# Altium schematic sheet Sensors-1.SchDoc(Sensors-1)
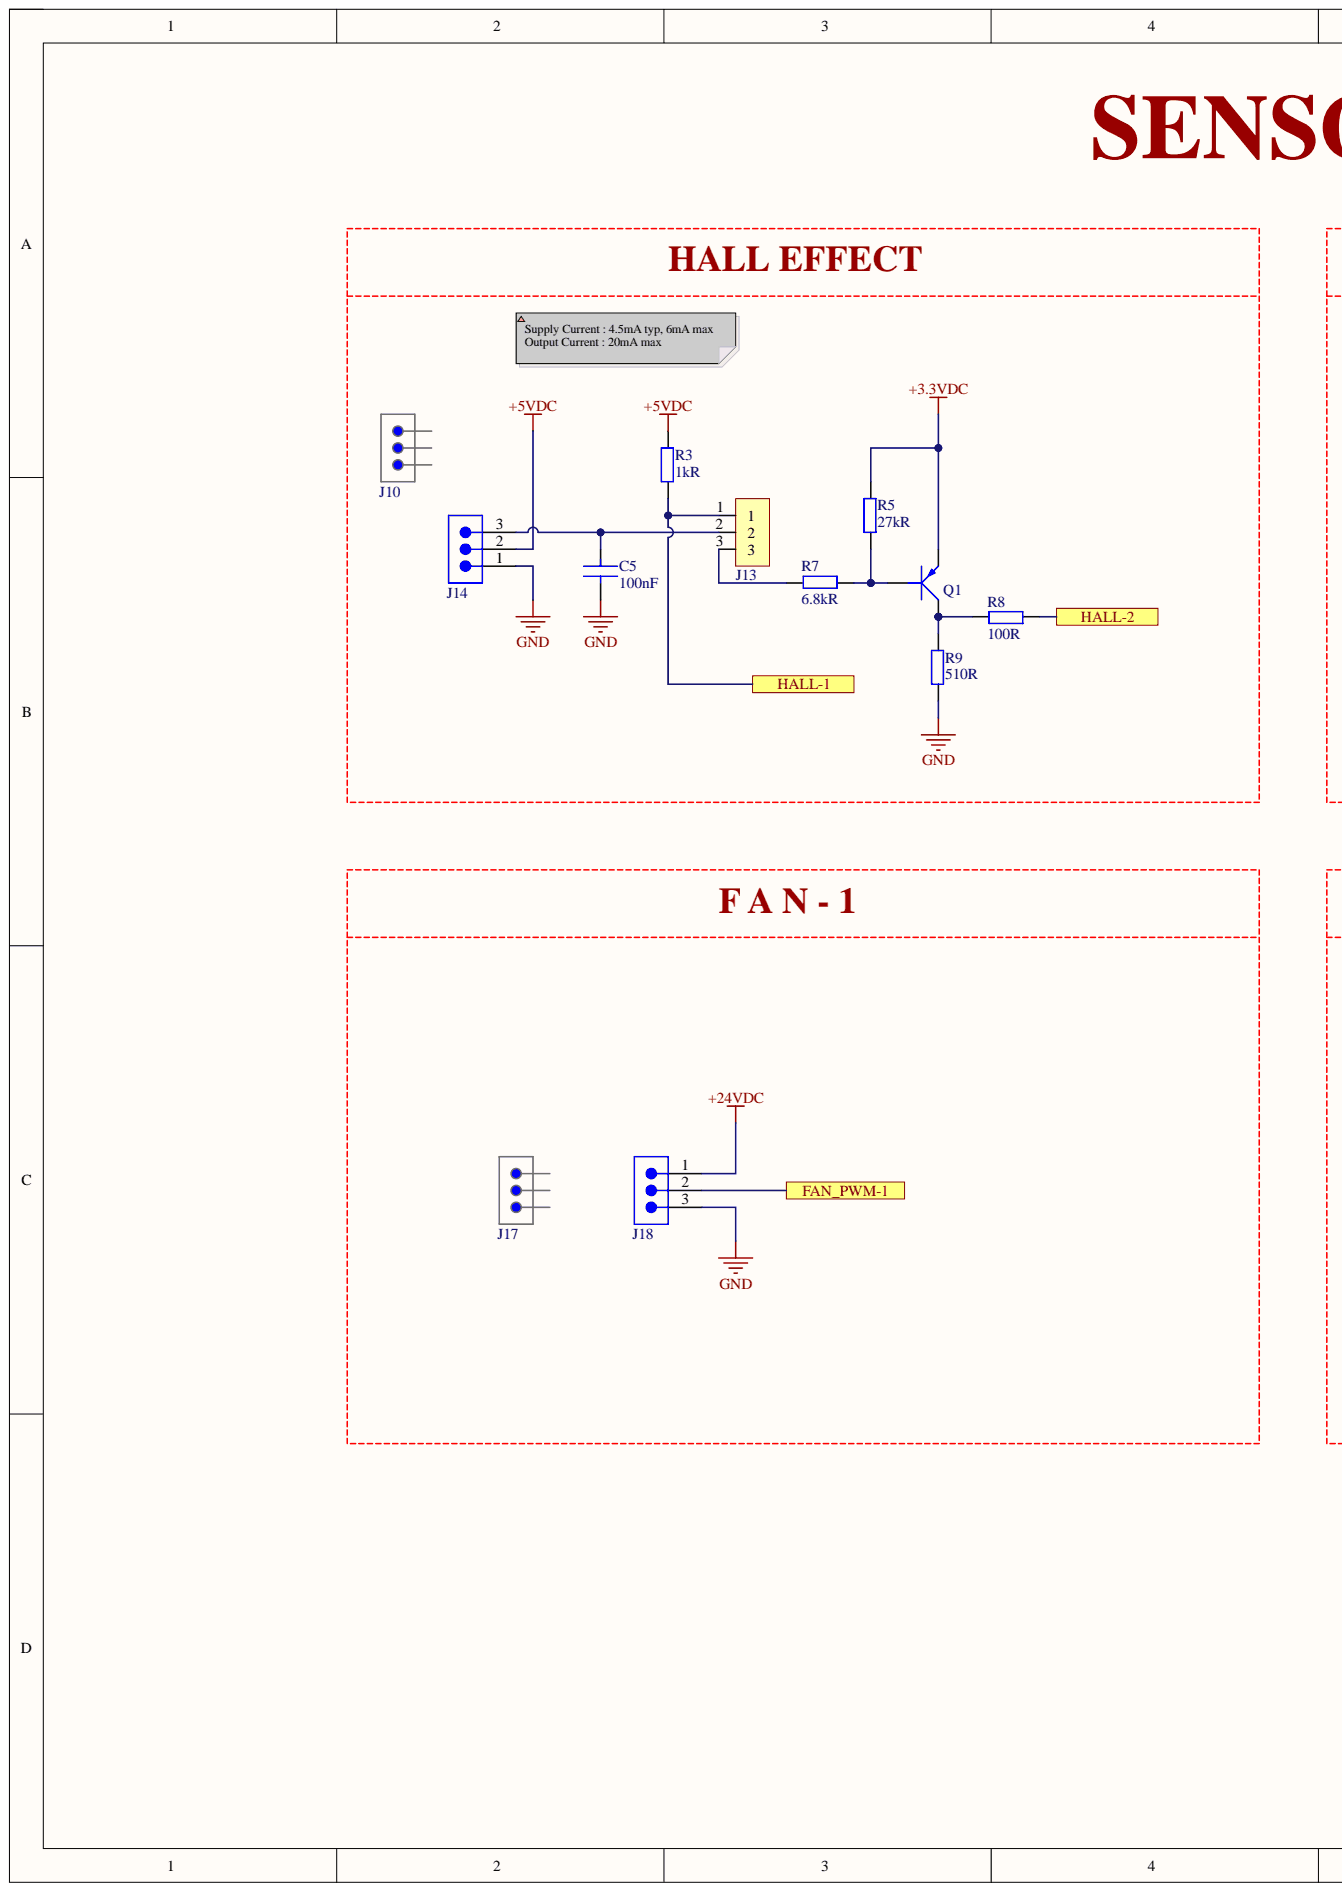
[diagram p0: Sensors-1.SchDoc, left]
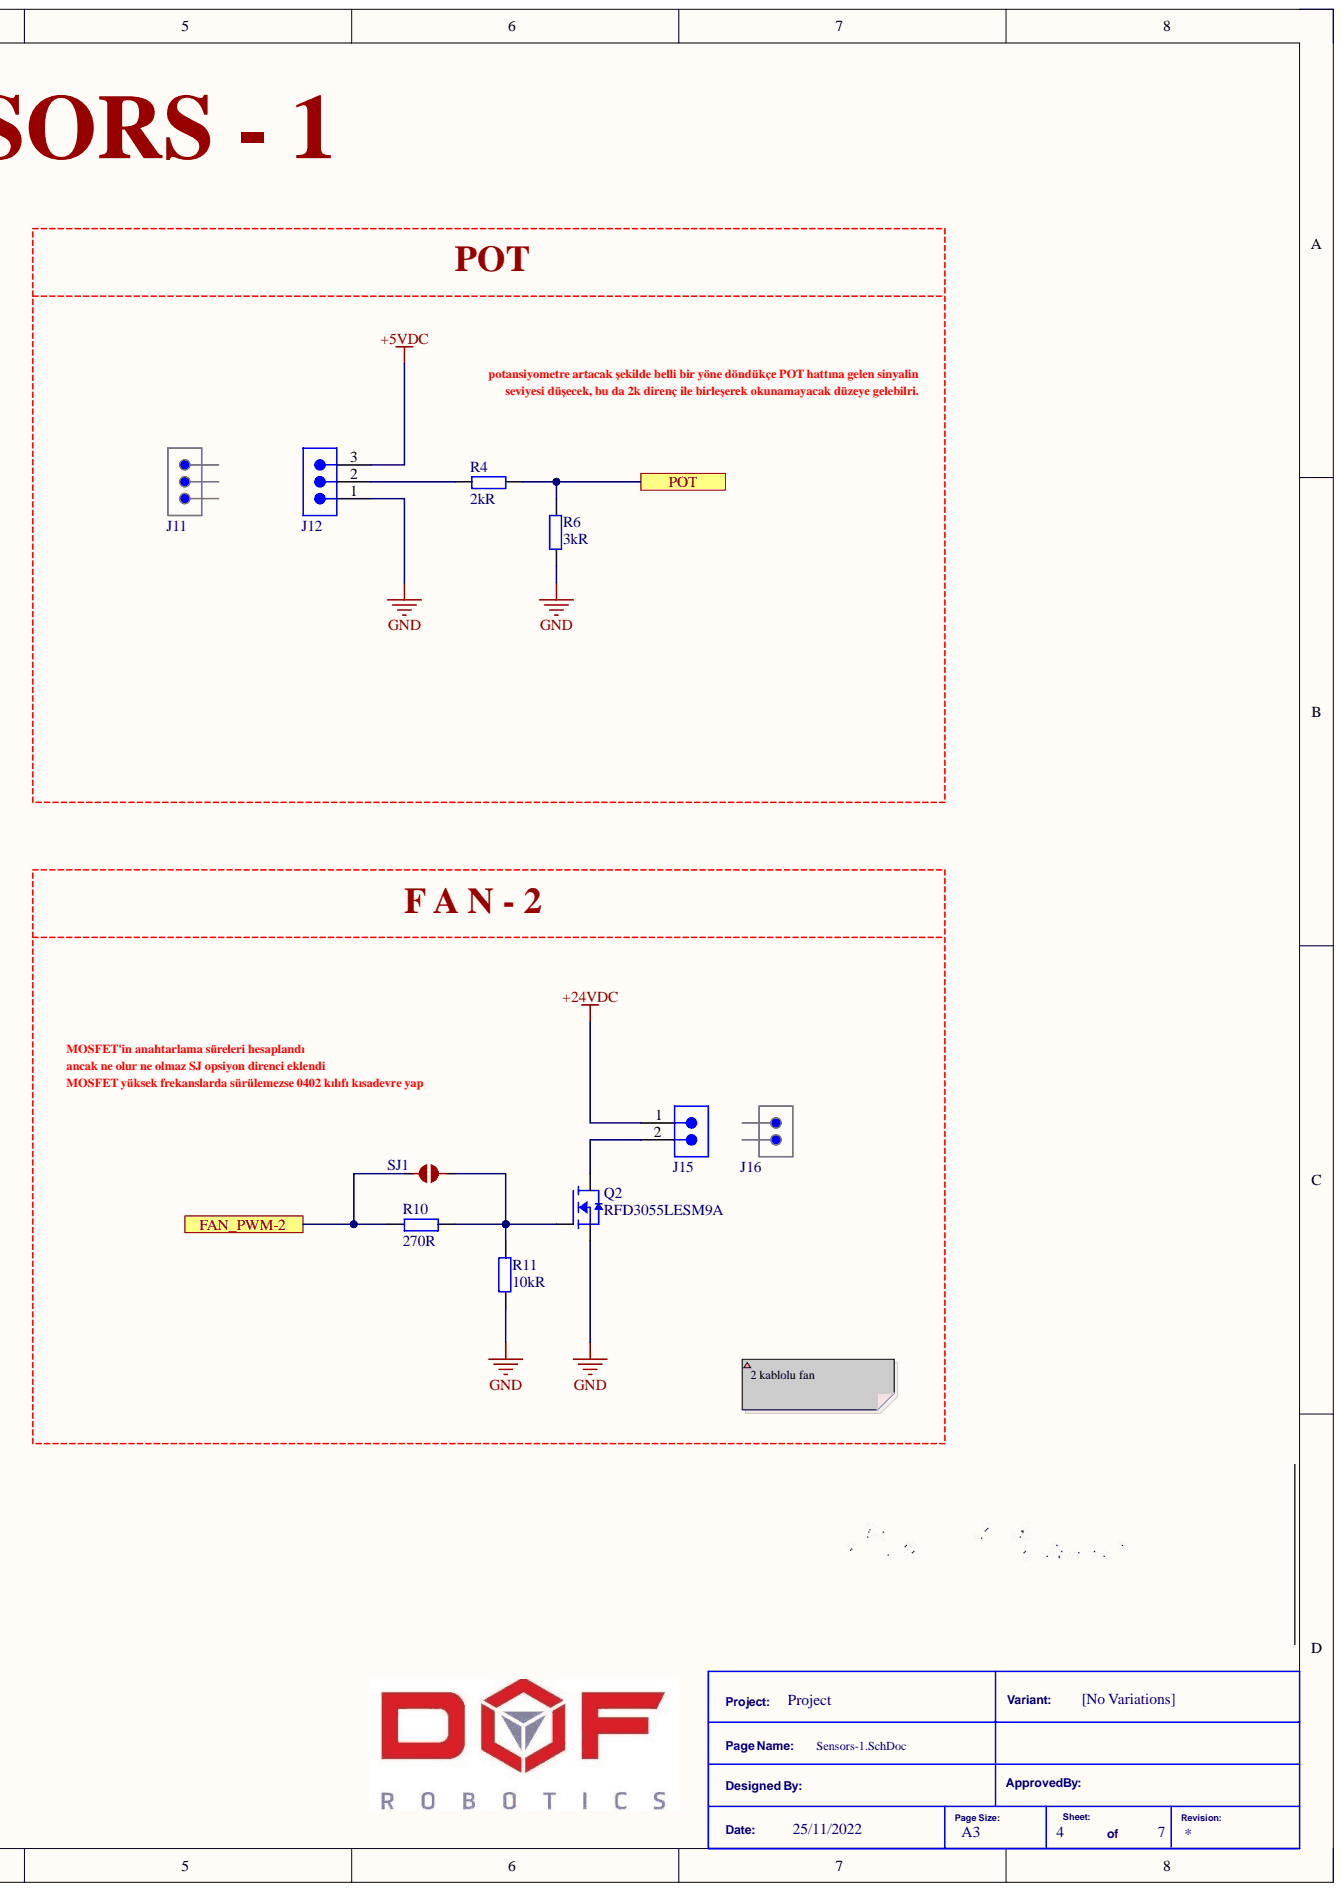
[diagram p1: Sensors-1.SchDoc, right]

## Parts
C5  Capacitance: 100nF  [CAP CER 0805]  (hidden comment: CL21B104KACNNNC)
J10  691352710003  [CONN FML 1X3 WURTH 691352710003]  (value not drawn)
J11  691352710003  [CONN FML 1X3 WURTH 691352710003]  (value not drawn)
J12  691313710003  [CONN ML 1X3 WURTH 691313710003]  (value not drawn)
J13  61300311121  [HDR_ML_1X3]  (value not drawn)
J14  691313710003  [CONN ML 1X3 WURTH 691313710003]  (value not drawn)
J15  691313710002  [CONN ML 1X2 WURTH 691313710002]  (value not drawn)
J16  691352710002  [CONN FML 1X2 WURTH 691352710002]  (value not drawn)
J17  691352710003  [CONN FML 1X3 WURTH 691352710003]  (value not drawn)
J18  691313710003  [CONN ML 1X3 WURTH 691313710003]  (value not drawn)
Q1  MMSS8550-H-TP  [SOT23-3]  (value not drawn)
Q2  RFD3055LESM9A  [TO-252AA]
R3  Resistance: 1kR  [RES 0603]  (hidden comment: ERJ-PA3J102V)
R4  Resistance: 2kR  [RES 0603]  (hidden comment: ERJ-3EKF2001V)
R5  Resistance: 27kR  [RES 0805]  (hidden comment: ERJ-6ENF2702V)
R6  Resistance: 3kR  [RES 0603]  (hidden comment: AC0603FR-073KL)
R7  Resistance: 6.8kR  [RES 0603]  (hidden comment: ERJ-PA3J682V)
R8  Resistance: 100R  [RES 0805]  (hidden comment: ERJ-P06J101V)
R9  Resistance: 510R  [RES 0603]  (hidden comment: ESR03EZPJ511)
R10  Resistance: 270R  [RES 0805]  (hidden comment: CRGCQ0805F270R)
R11  Resistance: 10kR  [RES 0805]  (hidden comment: RNCP0805FTD10K0)
SJ1  0402 jumper  [Res 0402]  (value not drawn)  (no BOM)

## Ports
FAN_PWM-1, FAN_PWM-2, HALL-1, HALL-2, POT

## Nets
+3.3VDC: Q1.E R5.2
+5VDC: J12.3 J14.2 R3.2
+24VDC: J15.1 J18.1
GND: C5.1 J12.1 J14.1 J18.3 Q2.2 R6.1 R9.1 R11.1
FAN_PWM-1: J18.2 ; port FAN_PWM-1
FAN_PWM-2: R10.2 SJ1.1 ; port FAN_PWM-2
HALL-1: J13.1 R3.1 ; port HALL-1
HALL-2: R8.1 ; port HALL-2
POT: R4.1 R6.2 ; port POT
NetC5_2: C5.2 J13.2 J14.3
NetJ12_2: J12.2 R4.2
NetJ13_3: J13.3 R7.1
NetJ15_2: J15.2 Q2.3
NetQ1_B: Q1.B R5.1 R7.2
NetQ1_C: Q1.C R8.2 R9.2
NetQ2_1: Q2.1 R10.1 R11.2 SJ1.2

## Library data of the parts
C5 = CAP CER 0.1UF 25V X7R 0805: Manufacturer: Samsung; Tolerance: 10%; Case Code (Imperial): 0805; Case Code (Metric): 2012; Case/Package: 0805; Category: Ceramic Capacitors; Component Kind: Standard; ComponentLink1Description: https://datasheet.ciiva.com/25801/cl21b104kacnnnc-25801824.pdf?src-supplier=Digi-Key; ComponentLink1URL: https://datasheet.ciiva.com/25801/cl21b104kacnnnc-25801824.pdf?src-supplier=Digi-Key; ComponentLink2Description: https://datasheet.ciiva.com/15216/getdatasheetpartid-355204-15216236.pdf?src-supplier=Verical; ComponentLink2URL: https://datasheet.ciiva.com/15216/getdatasheetpartid-355204-15216236.pdf?src-supplier=Verical; Construction: Flat; Contact Plating: Tin; Depth: 1.25mm; Description: CAP CER 0.1UF 25V X7R 0805; Dielectric: X7R; Height: 0.85mm; Length: 2mm; Manufacturer Part Number: CL21B104KACNNNC; Max Operating Temperature: 125°C; Min Operating Temperature: -55°C; Mount: Surface Mount; Package Quantity: 1; Packaging: Tape and Reel; Pricing 1: 1=0.10000, 10=0.04000, 50=0.02200, 100=0.01790, 500=0.01282, 1000=0.01007 (USD); Radiation Hardening: No; RoHS: Yes; RoHS Compliant: Yes; Series: CL; Stock 1: 2617; Supplier 1: Digi-Key; Supplier Part Number 1: 1276-1099-1-ND; Thickness: 0.037inch; Voltage: 25V; Voltage Rating: 25V; Width: 0.049inch; Library Name: LibEnes.SchLib
J10 J11 J17 = CONN FML 1X3 WURTH 691352710003: Pluggable Terminal Block, 3, 300 V, 12 A, 5 Mm, 24 Awg, 12 Awg Rohs Compliant: Yes  (Mate to 691313710003); Manufacturer: Wurth Electronics; Current: 20A; Current Rating: 12A; Number of Contacts: 3; Number of Levels: 1; Pitch: 0.197inch; Stripping Length: -7mm; Voltage: 300V; Wire Gauge (Max): 24AWG; Wire Gauge (Min): 12AWG; Category: Terminal Blocks; Color: Green; Component Kind: Standard; ComponentLink1Description: https://datasheet.ciiva.com/7992/1442233-7992380.pdf?src-supplier=Element14; ComponentLink1URL: https://datasheet.ciiva.com/7992/1442233-7992380.pdf?src-supplier=Element14; ComponentLink2Description: https://datasheet.ciiva.com/796/6913527100xx-796216.pdf?src-supplier=Digi-Key; ComponentLink2URL: https://datasheet.ciiva.com/796/6913527100xx-796216.pdf?src-supplier=Digi-Key; Contact Material: Brass; Length: 18.2mm; Manufacturer Part Number: 691352710003; Mount: Free Hanging; Number of Positions Per Level: 3; Package Quantity: 250; Packaging: Bulk; Pricing 1: 1=2.05000, 10=1.83900, 50=1.58800, 100=1.42100, 250=1.33700, 500=1.25400 (USD); REACH SVHC: Unknown; RoHS: Yes; RoHS Compliant: Yes; Series: WR-TBL Series 3527; Stock 1: 2478; Supplier 1: Digi-Key; Supplier Part Number 1: 732-2776-ND; Termination: Screw; Voltage Rating: 300V; Width: 15mm; Wire/Cable Gauge: 24AWG; Library Name: LibEnes.SchLib
J12 J14 J18 = CONN ML 1X3 WURTH 691313710003: 5mm Pitch Right Angle Pluggable Term Block; PCB Header; Through Hole; 3 Way  (Mate to 691352710003); Manufacturer: Wurth Electronics; Connector Type: PCB,Terminal Block; Current: 20A; Number of Contacts: 3; Number of Levels: 1; Pitch: 0.197inch; Voltage: 300V; Category: Terminal Blocks; Color: Green; Component Kind: Standard; ComponentLink1Description: https://datasheet.ciiva.com/8043/1442229-8043534.pdf?src-supplier=Element14; ComponentLink1URL: https://datasheet.ciiva.com/8043/1442229-8043534.pdf?src-supplier=Element14; ComponentLink2Description: https://datasheet.ciiva.com/795/6913137100xx-795838.pdf?src-supplier=Digi-Key; ComponentLink2URL: https://datasheet.ciiva.com/795/6913137100xx-795838.pdf?src-supplier=Digi-Key; ComponentLink3Description: https://datasheet.ciiva.com/48692/001088692-da-01-en-wr-tbl-terminal-block3137-691313710003-48692901.pdf?src-supplier=Conrad; ComponentLink3URL: https://datasheet.ciiva.com/48692/001088692-da-01-en-wr-tbl-terminal-block3137-691313710003-48692901.pdf?src-supplier=Conrad; Contact Material: Brass; Height: 12mm; Length: 12.5mm; Manufacturer Part Number: 691313710003; Mount: Through Hole; Number of Positions Per Level: 3; Number of Rows: 1; Package Quantity: 450; Packaging: Bulk; Pricing 1: 1=0.74000, 10=0.65700, 50=0.56800, 100=0.50800, 200=0.47800, 400=0.44800 (USD); REACH SVHC: Unknown; RoHS: Yes; RoHS Compliant: Yes; Series: WR-TBL Series 3137; Stock 1: 1376; Supplier 1: Digi-Key; Supplier Part Number 1: 732-2800-ND; Termination: Solder; Width: 17mm; Library Name: LibEnes.SchLib
J13 = HDR ML 1X3 WURTH 61300311121: CONN HEADER 3 POS 2.54; Manufacturer: Wurth Electronics; Connector Type: Male Pin; Gender: Male; Number of Contacts: 3; Pitch: 0.1inch; Category: Connectors; Color: Black; Component Kind: Standard; ComponentLink1Description: http://www.farnell.com/cad/1737268.pdf?src-supplier=Element14; ComponentLink1URL: http://www.farnell.com/cad/1737268.pdf?src-supplier=Element14; ComponentLink2Description: https://katalog.we-online.de/em/datasheet/6130xx11121.pdf?src-supplier=Digi-Key; ComponentLink2URL: https://katalog.we-online.de/em/datasheet/6130xx11121.pdf?src-supplier=Digi-Key; Contact Material: Copper; Contact Mating Length: 0.236inch; Contact Plating: Gold; Lead Free: Lead Free; Manufacturer Part Number: 61300311121; Mount: Through Hole; Number of Positions: 3; Number of Rows: 1; Packaging: Bulk; Pricing 1: 1=0.13000, 10=0.11300, 25=0.09400, 50=0.07900, 100=0.07100, 1000=0.06600 (USD); REACH SVHC: Unknown; RoHS: Yes; RoHS Compliant: Yes; Stock 1: 76429; Supplier 1: Digi-Key; Supplier Part Number 1: 732-5316-ND; Termination: Solder; Library Name: LibEnes.SchLib
J15 = CONN ML 1X2 WURTH 691313710002: 5mm Pitch Right Angle Pluggable Term Block; PCB Header; Through Hole; 2 Way  (Mate to 691352710002); Manufacturer: Wurth Electronics; Connector Type: PCB,Terminal Block; Current: 20A; Number of Contacts: 2; Number of Levels: 1; Pitch: 0.197inch; Voltage: 300V; Category: Terminal Blocks; Color: Green; Component Kind: Standard; ComponentLink1Description: https://datasheet.ciiva.com/795/6913137100xx-795778.pdf?src-supplier=Digi-Key; ComponentLink1URL: https://datasheet.ciiva.com/795/6913137100xx-795778.pdf?src-supplier=Digi-Key; ComponentLink2Description: https://datasheet.ciiva.com/8043/1442229-8043531.pdf?src-supplier=Element14; ComponentLink2URL: https://datasheet.ciiva.com/8043/1442229-8043531.pdf?src-supplier=Element14; ComponentLink3Description: https://datasheet.ciiva.com/48692/001088691-da-01-en-wr-tbl-terminal-block3137-691313710002-48692902.pdf?src-supplier=Conrad; ComponentLink3URL: https://datasheet.ciiva.com/48692/001088691-da-01-en-wr-tbl-terminal-block3137-691313710002-48692902.pdf?src-supplier=Conrad; Contact Material: Brass; Height: 12mm; Length: 12.5mm; Manufacturer Part Number: 691313710002; Mount: Through Hole; Number of Positions Per Level: 2; Number of Rows: 1; Package Quantity: 600; Packaging: Bulk; Pricing 1: 1=0.44000, 10=0.39400, 50=0.34000, 100=0.30500, 250=0.28600, 600=0.26900 (USD); REACH SVHC: Unknown; RoHS: Yes; RoHS Compliant: Yes; Series: WR-TBL Series 3137; Stock 1: 2898; Supplier 1: Digi-Key; Supplier Part Number 1: 732-2799-ND; Termination: Solder; Width: 12mm; Library Name: LibEnes.SchLib
J16 = CONN FML 1X2 WURTH 691352710002: Conn Terminal Block F 2 POS 5mm Screw RA Cable Mount 20A Box    (Mate to 691313710002); Manufacturer: Wurth Electronics; Current Rating: 12A; Number of Contacts: 2; Number of Levels: 1; Pitch: 0.197inch; Stripping Length: -7mm; Voltage: 300V; Wire Gauge (Max): 24AWG; Wire Gauge (Min): 12AWG; Category: Terminal Blocks; Color: Green; Component Kind: Standard; ComponentLink1Description: https://datasheet.ciiva.com/7992/1442233-7992379.pdf?src-supplier=Element14; ComponentLink1URL: https://datasheet.ciiva.com/7992/1442233-7992379.pdf?src-supplier=Element14; ComponentLink2Description: https://datasheet.ciiva.com/796/6913527100xx-796063.pdf?src-supplier=Digi-Key; ComponentLink2URL: https://datasheet.ciiva.com/796/6913527100xx-796063.pdf?src-supplier=Digi-Key; Contact Material: Brass; Current: 20A; Lead Free: Lead Free; Length: 18.2mm; Manufacturer Part Number: 691352710002; Mount: Free Hanging; Number of Positions Per Level: 2; Package Quantity: 350; Packaging: Bulk; REACH SVHC: Unknown; RoHS: Yes; RoHS Compliant: Yes; Series: WR-TBL Series 3527; Stock 1: 2782; Supplier 1: Digi-Key; Supplier Part Number 1: 732-2775-ND; Supplier Unit Price 1: 1=1.32000, 10=1.18200, 50=1.02200, 100=0.91400, 350=0.85900, 700=0.80500 (USD); Termination: Screw; Voltage Rating: 300V; Width: 10mm; Wire/Cable Gauge: 24AWG; Library Name: LibEnes.SchLib
Q1 = BJT PNP MMSS8550-H-TP: PNP Ic=1.5A Vceo=25V hfe=120~350 fT=100MHz; Manufacturer: MCC; Collector Base Voltage (VCBO): 40V; Collector Emitter Breakdown Voltage: 25V; Collector Emitter Saturation Voltage: 500mV; Element Configuration: Single; Emitter Base Voltage (VEBO): 1.6V; Gain Bandwidth Product: 100MHz; hFE Min: 40; Max Collector Current: 1.5A; Max Power Dissipation: 625mW; Case/Package: SOT-23; Category: BJTs; Collector Emitter Voltage (VCEO): 500mV; Collector-emitter Voltage-Max: 500mV; Component Kind: Standard; ComponentLink1Description: https://datasheet.ciiva.com/16285/mmss8550sot-23-12703-16285519.pdf?src-supplier=Mouser; ComponentLink1URL: https://datasheet.ciiva.com/16285/mmss8550sot-23-12703-16285519.pdf?src-supplier=Mouser; ComponentLink2Description: https://datasheet.ciiva.com/17380/mmss8550sot-23-17380226.pdf?src-supplier=Future+Electronics; ComponentLink2URL: https://datasheet.ciiva.com/17380/mmss8550sot-23-17380226.pdf?src-supplier=Future+Electronics; ComponentLink3Description: https://datasheet.ciiva.com/6356/mmss8550sot-23-6356926.pdf?src-supplier=Digi-Key; ComponentLink3URL: https://datasheet.ciiva.com/6356/mmss8550sot-23-6356926.pdf?src-supplier=Digi-Key; ComponentLink4Description: https://datasheet.ciiva.com/6356/mmss8550sot-23-6356927.pdf?src-supplier=Digi-Key; ComponentLink4URL: https://datasheet.ciiva.com/6356/mmss8550sot-23-6356927.pdf?src-supplier=Digi-Key; ComponentLink5Description: https://datasheet.ciiva.com/6356/mmss8550sot-23-6356925.pdf?src-supplier=Digi-Key; ComponentLink5URL: https://datasheet.ciiva.com/6356/mmss8550sot-23-6356925.pdf?src-supplier=Digi-Key; Lead Free: Lead Free; Manufacturer Part Number: MMSS8550-H-TP; Max Breakdown Voltage: 25V; Max Operating Temperature: 150°C; Min Operating Temperature: -55°C; Mount: Surface Mount; Package Quantity: 1; Packaging: Tape and Reel; Polarity: PNP; Pricing 1: 1=0.27000, 10=0.22000, 100=0.11690, 500=0.07692, 1000=0.05230 (USD); RoHS: Yes; RoHS Compliant: Yes; Series: -; Stock 1: 100985; Supplier 1: Digi-Key; Supplier Part Number 1: MMSS8550-H-TPMSCT-ND; Transition Frequency: 100MHz; Library Name: LibEnes.SchLib
Q2 = MOS NPN RFD3055LESM9A: Trans MOSFET N-CH 60V 11A 3-Pin(2+Tab) DPAK; Manufacturer: ON Semiconductor / Fairchild; Drain to Source Resistance: 107mR; Element Configuration: Single; Fall Time: 39ns; Gate to Source Voltage (Vgs): 16V; Input Capacitance: 350pF; Max Power Dissipation: 38W; Nominal Vgs: 3V; Number of Elements: 1; Polarity: N-CHANNEL; Rise Time: 105ns; Turn-Off Delay Time: 22ns; Turn-On Delay Time: 8ns; Case/Package: TO-252AA; Category: MOSFETs; Component Kind: Standard; ComponentLink1Description: https://datasheet.ciiva.com/15137/getdatasheetpartid-41783-15137104.pdf?src-supplier=Verical; ComponentLink1URL: https://datasheet.ciiva.com/15137/getdatasheetpartid-41783-15137104.pdf?src-supplier=Verical; ComponentLink2Description: https://datasheet.ciiva.com/4440/rfd3055lesm-191788-4440290.pdf?src-supplier=Mouser; ComponentLink2URL: https://datasheet.ciiva.com/4440/rfd3055lesm-191788-4440290.pdf?src-supplier=Mouser; ComponentLink3Description: https://datasheet.ciiva.com/11175/rfd3055lesm-11175893.pdf?src-supplier=Avnet; ComponentLink3URL: https://datasheet.ciiva.com/11175/rfd3055lesm-11175893.pdf?src-supplier=Avnet; ComponentLink4Description: https://datasheet.ciiva.com/46440/1755766-46440309.pdf?src-supplier=Element14; ComponentLink4URL: https://datasheet.ciiva.com/46440/1755766-46440309.pdf?src-supplier=Element14; ComponentLink5Description: https://datasheet.ciiva.com/932/rfd3055lesm-932438.pdf?src-supplier=Digi-Key; ComponentLink5URL: https://datasheet.ciiva.com/932/rfd3055lesm-932438.pdf?src-supplier=Digi-Key; ComponentLink6Description: https://datasheet.ciiva.com/932/rfd3055lesm-932439.pdf?src-supplier=Digi-Key; ComponentLink6URL: https://datasheet.ciiva.com/932/rfd3055lesm-932439.pdf?src-supplier=Digi-Key; ComponentLink7Description: https://datasheet.ciiva.com/932/rfd3055lesm-932440.pdf?src-supplier=Digi-Key; ComponentLink7URL: https://datasheet.ciiva.com/932/rfd3055lesm-932440.pdf?src-supplier=Digi-Key; ComponentLink8Description: https://datasheet.ciiva.com/11175/rfd3055lesm-11175208.pdf?src-supplier=Avnet; ComponentLink8URL: https://datasheet.ciiva.com/11175/rfd3055lesm-11175208.pdf?src-supplier=Avnet; ComponentLink9Description: https://datasheet.ciiva.com/22671/1755766-22671992.pdf?src-supplier=Element14; ComponentLink9URL: https://datasheet.ciiva.com/22671/1755766-22671992.pdf?src-supplier=Element14; ComponentLink10Description: https://datasheet.ciiva.com/48715/001265031-da-01-en-mosfet-n-ch-rfd3055lesm9a-to-252-3-fsc-48715607.pdf?src-supplier=Conrad; ComponentLink10URL: https://datasheet.ciiva.com/48715/001265031-da-01-en-mosfet-n-ch-rfd3055lesm9a-to-252-3-fsc-48715607.pdf?src-supplier=Conrad; Contact Plating: Tin,Matte; Continuous Drain Current (ID): 11A; Current Rating: 11A; Drain to Source Breakdown Voltage: 60V; Drain to Source Voltage (Vdss): 60V; Drain-source On Resistance-Max: 107mR; Height: 2.39mm; Lead Free: Lead Free; Length: 6.73mm; Manufacturer Part Number: RFD3055LESM9A; Max Operating Temperature: 175°C; Min Operating Temperature: -55°C; Mount: Surface Mount; Number of Pins: 3; On-State Resistance: 107mR; Package Quantity: 1; Packaging: Tape and Reel; Power Dissipation: 38W; Pricing 1: 1=0.85000, 10=0.74600, 100=0.57200, 500=0.45220, 1000=0.36176 (USD); Radiation Hardening: No; Rds On Max: 107mR; REACH SVHC: No SVHC; RoHS: Yes; RoHS Compliant: Yes; Series: -; Stock 1: 75083; Supplier 1: Digi-Key; Supplier Part Number 1: RFD3055LESM9ACT-ND; Threshold Voltage: 3V; Voltage Rating (DC): 60V; Weight: 0.26037g; Width: 6.22mm; Library Name: LibEnes.SchLib
R3 = RES 1KR 5% 0.25W 0603: Res Thick Film 0603 1K Ohm 5% 0.25W(1/4W) ±200ppm/C Pad SMD Automotive T/R; Manufacturer: Panasonic; Max Power Dissipation: 250mW; Temperature Coefficient: 200ppm/°C; Case Code (Imperial): 0603; Case Code (Metric): 1608; Case/Package: 0603; Category: Chip SMD Resistors; Component Kind: Standard; ComponentLink1Description: https://industrial.panasonic.com/ww/system/files/data/download/catalog/id_fixed-resistors_e_1.pdf?src-supplier=Digi-Key; ComponentLink1URL: https://industrial.panasonic.com/ww/system/files/data/download/catalog/id_fixed-resistors_e_1.pdf?src-supplier=Digi-Key; Features: Automotive AEC-Q200; Height: 0.018inch; Length: 0.063inch; Manufacturer Part Number: ERJ-PA3J102V; Max Operating Temperature: 155°C; Min Operating Temperature: -55°C; Number of Terminations: 2; Packaging: Tape and Reel; Pricing 1: 1=0.11000, 10=0.09600, 100=0.03710, 1000=0.01631, 2500=0.01408 (USD); Resistor Type: Thick Film; RoHS: Yes; Stock 1: 25628; Supplier 1: Digi-Key; Supplier Part Number 1: P1KBZCT-ND; Tolerance: 5%; Width: 0.031inch; Library Name: LibEnes.SchLib
R4 = RES 2KR 1% 0.1W 0603: Res Thick Film 0603 2K Ohm 1% 1/10W ±100ppm/°C Molded SMD Punched Carrier T/R; Manufacturer: Panasonic; Max Power Dissipation: 100mW; Voltage Rating: 75V; Voltage Rating (DC): 50V; Case Code (Imperial): 0603; Case Code (Metric): 1608; Case/Package: 0603; Category: Chip SMD Resistors; Component Kind: Standard; ComponentLink1Description: https://datasheet.ciiva.com/43460/1678782-43460485.pdf?src-supplier=Farnell; ComponentLink1URL: https://datasheet.ciiva.com/43460/1678782-43460485.pdf?src-supplier=Farnell; ComponentLink2Description: https://datasheet.ciiva.com/6082/1678782-6082059.pdf?src-supplier=Farnell; ComponentLink2URL: https://datasheet.ciiva.com/6082/1678782-6082059.pdf?src-supplier=Farnell; ComponentLink3Description: https://datasheet.ciiva.com/46377/1678782-46377366.pdf?src-supplier=Element14; ComponentLink3URL: https://datasheet.ciiva.com/46377/1678782-46377366.pdf?src-supplier=Element14; ComponentLink4Description: https://datasheet.ciiva.com/28673/1678782-28673990.pdf?src-supplier=Element14; ComponentLink4URL: https://datasheet.ciiva.com/28673/1678782-28673990.pdf?src-supplier=Element14; ComponentLink5Description: https://datasheet.ciiva.com/24027/aoa0000ce2-24027453.pdf?src-supplier=Mouser; ComponentLink5URL: https://datasheet.ciiva.com/24027/aoa0000ce2-24027453.pdf?src-supplier=Mouser; ComponentLink6Description: https://datasheet.ciiva.com/16771/70260748-16771369.pdf?src-supplier=Allied+Electronics; ComponentLink6URL: https://datasheet.ciiva.com/16771/70260748-16771369.pdf?src-supplier=Allied+Electronics; ComponentLink7Description: https://datasheet.ciiva.com/16200/aoa0000ce2-16200501.pdf?src-supplier=Future+Electronics; ComponentLink7URL: https://datasheet.ciiva.com/16200/aoa0000ce2-16200501.pdf?src-supplier=Future+Electronics; ComponentLink8Description: https://datasheet.ciiva.com/30064/aoa0000ce2-30064920.pdf?src-supplier=Onlinecomponents.com; ComponentLink8URL: https://datasheet.ciiva.com/30064/aoa0000ce2-30064920.pdf?src-supplier=Onlinecomponents.com; Construction: Rectangular; Contact Plating: Tin; Depth: 0.8mm; Features: Automotive AEC-Q200; Height: 0.022inch; Lead Free: Lead Free; Length: 1.6mm; Manufacturer Part Number: ERJ-3EKF2001V; Max Operating Temperature: 155°C; Military Standard: Not; Min Operating Temperature: -55°C; Mount: Surface Mount; Number of Terminations: 2; Package Quantity: 1; Packaging: Tape and Reel; Power Rating: 100mW; Pricing 1: 1=0.10000, 10=0.04800, 100=0.01930, 1000=0.00868, 2500=0.00754 (USD); Radiation Hardening: No; REACH SVHC: No SVHC; Resistance Tolerance: 1%; Resistor Type: Thick Film; RoHS: Yes; RoHS Compliant: Yes; Series: ERJ; Size Code: 0603; Stock 1: 1368069; Supplier 1: Digi-Key; Supplier Part Number 1: P2.00KHCT-ND; Temperature Coefficient: 100ppm/°C; Termination: SMD/SMT; Tolerance: 1%; Width: 0.031inch; Library Name: LibEnes.SchLib
R5 = RES 27KR 0805: Resistor;  Thick Film;  Res 27 Kilohms;  Pwr-Rtg 0.125 W;  Tol 1%;  SMT;  0805;  Tape & Reel; Manufacturer: Panasonic; Max Power Dissipation: 125mW; Temperature Coefficient: 100ppm/°C; Voltage Rating: 150V; Case Code (Imperial): 0805; Case Code (Metric): 2012; Case/Package: 0805; Category: Chip SMD Resistors; Component Kind: Standard; ComponentLink1Description: https://datasheet.ciiva.com/11821/0900766b80f80784-11821581.pdf?src-supplier=RSComponents; ComponentLink1URL: https://datasheet.ciiva.com/11821/0900766b80f80784-11821581.pdf?src-supplier=RSComponents; ComponentLink2Description: https://datasheet.ciiva.com/46373/1678782-46373269.pdf?src-supplier=Element14; ComponentLink2URL: https://datasheet.ciiva.com/46373/1678782-46373269.pdf?src-supplier=Element14; ComponentLink3Description: https://datasheet.ciiva.com/7887/426256-7887032.pdf?src-supplier=Element14; ComponentLink3URL: https://datasheet.ciiva.com/7887/426256-7887032.pdf?src-supplier=Element14; ComponentLink4Description: https://datasheet.ciiva.com/9191/aoa0000ce2-9191273.pdf?src-supplier=Avnet; ComponentLink4URL: https://datasheet.ciiva.com/9191/aoa0000ce2-9191273.pdf?src-supplier=Avnet; ComponentLink5Description: https://datasheet.ciiva.com/17210/aoa0000ce2-17210633.pdf?src-supplier=Avnet+Europe; ComponentLink5URL: https://datasheet.ciiva.com/17210/aoa0000ce2-17210633.pdf?src-supplier=Avnet+Europe; ComponentLink6Description: https://datasheet.ciiva.com/22673/1678782-22673705.pdf?src-supplier=Element14; ComponentLink6URL: https://datasheet.ciiva.com/22673/1678782-22673705.pdf?src-supplier=Element14; ComponentLink7Description: https://datasheet.ciiva.com/22687/1678782-22687081.pdf?src-supplier=Element14; ComponentLink7URL: https://datasheet.ciiva.com/22687/1678782-22687081.pdf?src-supplier=Element14; ComponentLink8Description: https://datasheet.ciiva.com/16512/aoa0000ce2-16512218.pdf?src-supplier=Avnet+Asia; ComponentLink8URL: https://datasheet.ciiva.com/16512/aoa0000ce2-16512218.pdf?src-supplier=Avnet+Asia; ComponentLink9Description: https://datasheet.ciiva.com/16760/70260748-16760047.pdf?src-supplier=Allied+Electronics; ComponentLink9URL: https://datasheet.ciiva.com/16760/70260748-16760047.pdf?src-supplier=Allied+Electronics; ComponentLink10Description: https://datasheet.ciiva.com/30053/aoa0000ce2-30053807.pdf?src-supplier=Onlinecomponents.com; ComponentLink10URL: https://datasheet.ciiva.com/30053/aoa0000ce2-30053807.pdf?src-supplier=Onlinecomponents.com; Construction: Rectangular; Contact Plating: Tin; Depth: 1.25mm; Features: Automotive AEC-Q200; Height: 0.6mm; Length: 2mm; Manufacturer Part Number: ERJ-6ENF2702V; Max Operating Temperature: 155°C; Military Standard: Not; Min Operating Temperature: -55°C; Mount: Surface Mount; Number of Pins: 2; Number of Terminations: 2; Package Quantity: 1; Packaging: Tape and Reel; Power Rating: 125mW; Pricing 1: 1=0.10000, 10=0.07500, 100=0.02880, 1000=0.01265, 2500=0.01092 (USD); Radiation Hardening: No; REACH SVHC: No SVHC; Resistance Tolerance: 1%; Resistor Type: Thick Film; RoHS: Yes; RoHS Compliant: Yes; Series: ERJ; Stock 1: 154450; Supplier 1: Digi-Key; Supplier Part Number 1: P27.0KCCT-ND; Technology: THICK FILM; Termination: SMD/SMT; Tolerance: 1%; Voltage Rating (DC): 150V; Width: 1.2mm; Library Name: LibEnes.SchLib
R6 = RES 3KR 1% 0.1W 0603: Res Thick Film 0603 3K Ohm 1% 0.1W(1/10W) ±100ppm/C Pad SMD Automotive T/R; Manufacturer: Yageo; Max Power Dissipation: 100mW; Temperature Coefficient: 100ppm/°C; Voltage Rating: 50V; Case Code (Imperial): 0603; Case Code (Metric): 1608; Case/Package: 0603; Category: Chip SMD Resistors; Component Kind: Standard; ComponentLink1Description: http://www.mouser.com/ds/2/447/yageodocoutput-1111992.pdf?src-supplier=Mouser; ComponentLink1URL: http://www.mouser.com/ds/2/447/yageodocoutput-1111992.pdf?src-supplier=Mouser; ComponentLink2Description: http://www.yageo.com/NewPortal/yageodocoutput?fileName=/pdf/R-Chip/PYu-AC_51_RoHS_L_6.pdf&src-supplier=Digi-Key; ComponentLink2URL: http://www.yageo.com/NewPortal/yageodocoutput?fileName=/pdf/R-Chip/PYu-AC_51_RoHS_L_6.pdf&src-supplier=Digi-Key; ComponentLink3Description: https://www.yageo.com/upload/media/product/productsearch/datasheet/rchip/PYu-AC_51_RoHS_L_7.pdf?src-supplier=Digi-Key; ComponentLink3URL: https://www.yageo.com/upload/media/product/productsearch/datasheet/rchip/PYu-AC_51_RoHS_L_7.pdf?src-supplier=Digi-Key; Features: Moisture Resistant,Automotive AEC-Q200; Height: 0.022inch; Lead Free: Lead Free; Length: 0.063inch; Manufacturer Part Number: AC0603FR-073KL; Max Operating Temperature: 155°C; Min Operating Temperature: -55°C; Number of Terminations: 2; Packaging: Tape and Reel; Power Rating: 100mW; Pricing 1: 1=0.10000, 10=0.02600, 100=0.01050, 1000=0.00472, 2500=0.00410 (USD); Resistor Type: Thick Film; RoHS: Yes; RoHS Compliant: Yes; Series: AC; Stock 1: 127930; Supplier 1: Digi-Key; Supplier Part Number 1: YAG3607CT-ND; Termination: SMD/SMT; Tolerance: 1%; Width: 0.031inch; Library Name: LibEnes.SchLib
R7 = RES 6.8KR 5% 0.25W 0603: RES SMD 6.8K OHM 5% 1/3W 0603; Manufacturer: Panasonic; Max Power Dissipation: 250mW; Temperature Coefficient: 200ppm/°C; Case Code (Imperial): 0603; Case Code (Metric): 1608; Case/Package: 0603; Category: Chip SMD Resistors; Component Kind: Standard; ComponentLink1Description: https://industrial.panasonic.com/cdbs/www-data/pdf/RDO0000/AOA0000C331.pdf?src-supplier=Digi-Key; ComponentLink1URL: https://industrial.panasonic.com/cdbs/www-data/pdf/RDO0000/AOA0000C331.pdf?src-supplier=Digi-Key; Features: Automotive AEC-Q200; Height: 0.018inch; Length: 0.063inch; Manufacturer Part Number: ERJ-PA3J682V; Max Operating Temperature: 155°C; Min Operating Temperature: -55°C; Number of Terminations: 2; Packaging: Tape and Reel; Pricing 1: 1=0.11000, 10=0.09600, 100=0.03710, 1000=0.01631, 2500=0.01408 (USD); Resistor Type: Thick Film; RoHS: Yes; Stock 1: 20344; Supplier 1: Digi-Key; Supplier Part Number 1: P6.8KBZCT-ND; Tolerance: 5%; Width: 0.031inch; Library Name: LibEnes.SchLib
R8 = RES 100R 5% 0.5W 0805: Res Thick Film 0805 100 Ohm 5% 0.5W ±200ppm/°C Molded SMD Punched Carrier T/R; Manufacturer: Panasonic; Max Power Dissipation: 500mW; Voltage Rating: 400V; Voltage Rating (DC): 150V; Case Code (Imperial): 0805; Case Code (Metric): 2012; Case/Package: 0805; Category: Chip SMD Resistors; Component Kind: Standard; ComponentLink1Description: https://datasheet.ciiva.com/11810/0900766b812cb812-11810156.pdf?src-supplier=RSComponents; ComponentLink1URL: https://datasheet.ciiva.com/11810/0900766b812cb812-11810156.pdf?src-supplier=RSComponents; ComponentLink2Description: https://datasheet.ciiva.com/7831/aoa0000ce4-7831000.pdf?src-supplier=Element14; ComponentLink2URL: https://datasheet.ciiva.com/7831/aoa0000ce4-7831000.pdf?src-supplier=Element14; ComponentLink3Description: https://datasheet.ciiva.com/24163/aoa0000ce4-64075-24163318.pdf?src-supplier=Mouser; ComponentLink3URL: https://datasheet.ciiva.com/24163/aoa0000ce4-64075-24163318.pdf?src-supplier=Mouser; ComponentLink4Description: https://datasheet.ciiva.com/16788/70068906-16788678.pdf?src-supplier=Allied+Electronics; ComponentLink4URL: https://datasheet.ciiva.com/16788/70068906-16788678.pdf?src-supplier=Allied+Electronics; ComponentLink5Description: https://datasheet.ciiva.com/16767/70068906-16767564.pdf?src-supplier=Allied+Electronics; ComponentLink5URL: https://datasheet.ciiva.com/16767/70068906-16767564.pdf?src-supplier=Allied+Electronics; ComponentLink6Description: https://datasheet.ciiva.com/9186/aoa0000ce4-9186844.pdf?src-supplier=Avnet; ComponentLink6URL: https://datasheet.ciiva.com/9186/aoa0000ce4-9186844.pdf?src-supplier=Avnet; Construction: Rectangular; Contact Plating: Tin; Depth: 1.25mm; Features: Automotive AEC-Q200; Height: 0.6mm; Lead Free: Lead Free; Length: 2mm; Manufacturer Part Number: ERJ-P06J101V; Max Operating Temperature: 155°C; Military Standard: Not; Min Operating Temperature: -55°C; Mount: Surface Mount; Number of Terminations: 2; Package Quantity: 1; Packaging: Tape and Reel; Power Rating: 500mW; Pricing 1: 1=0.12000, 10=0.10600, 100=0.04070, 1000=0.01790, 2500=0.01546 (USD); REACH SVHC: Unknown; Resistance Tolerance: 5%; Resistor Type: Thick Film; RoHS: Yes; RoHS Compliant: Yes; Series: ERJ; Stock 1: 27836; Supplier 1: Digi-Key; Supplier Part Number 1: P100ADCT-ND; Technology: THICK FILM; Temperature Coefficient: 200ppm/°C; Termination: SMD/SMT; Tolerance: 5%; Weight: 0.000194oz; Width: 1.2mm; Library Name: LibEnes.SchLib
R9 = RES 510R 5% 0.25W 0603: Res Thick Film 0603 510 Ohm 5% 1/4W +/-200ppm/ßC Molded SMD SMD Paper T/R; Manufacturer: Rohm; Max Power Dissipation: 250mW; Temperature Coefficient: 200ppm/°C; Case Code (Imperial): 0603; Case Code (Metric): 1608; Case/Package: 0603; Category: Chip SMD Resistors; Component Kind: Standard; ComponentLink1Description: http://www.rohm.com/web/global/search/parametric/-/search/generateQRCode/dsheet/22/1/40101045/ESR10EZPD?src-supplier=Avnet; ComponentLink1URL: http://www.rohm.com/web/global/search/parametric/-/search/generateQRCode/dsheet/22/1/40101045/ESR10EZPD?src-supplier=Avnet; ComponentLink2Description: https://www.rohm.com/datasheet/ESR01MZPF/esr-e?src-supplier=Digi-Key; ComponentLink2URL: https://www.rohm.com/datasheet/ESR01MZPF/esr-e?src-supplier=Digi-Key; Contact Plating: Tin,Matte; Features: Automotive AEC-Q200; Lead Free: Lead Free; Manufacturer Part Number: ESR03EZPJ511; Max Operating Temperature: 155°C; Min Operating Temperature: -55°C; Number of Terminations: 2; Packaging: Tape and Reel; Pricing 1: 1=0.13000, 10=0.12100, 100=0.04640, 1000=0.02039, 2500=0.01761 (USD); Radiation Hardening: No; Resistor Type: Thick Film; RoHS: Yes; RoHS Compliant: Yes; Series: ESR; Stock 1: 144888; Supplier 1: Digi-Key; Supplier Part Number 1: RHM510DDKR-ND; Tolerance: 5%; Library Name: LibEnes.SchLib
R10 = RES 270R 1% 0.125W 0805: Res Thick Film 0805 270 Ohm 1% 0.125W(1/8W) ±100ppm/C Pad SMD Automotive T/R; Manufacturer: TE Connectivity; Category: Chip SMD Resistors; Component Kind: Standard; ComponentLink1Description: https://www.te.com/commerce/DocumentDelivery/DDEController?Action=srchrtrv&amp;DocNm=1773204-3&amp;DocType=DS&amp;DocLang=English&src-supplier=Digi-Key; ComponentLink1URL: https://www.te.com/commerce/DocumentDelivery/DDEController?Action=srchrtrv&amp;DocNm=1773204-3&amp;DocType=DS&amp;DocLang=English&src-supplier=Digi-Key; ComponentLink2Description: https://www.te.com/commerce/DocumentDelivery/DDEController?Action=srchrtrv&DocNm=1773204-3&DocType=DS&DocLang=English&src-supplier=Digi-Key; ComponentLink2URL: https://www.te.com/commerce/DocumentDelivery/DDEController?Action=srchrtrv&DocNm=1773204-3&DocType=DS&DocLang=English&src-supplier=Digi-Key; Manufacturer Part Number: CRGCQ0805F270R; Pricing 1: 1=0.10000, 10=0.04800, 100=0.01960, 1000=0.00879, 2500=0.00763 (USD); RoHS: Yes; Stock 1: 130113; Supplier 1: Digi-Key; Supplier Part Number 1: A129742CT-ND; Library Name: LibEnes.SchLib
R11 = RES 10K 0805: Res Thin Film 0805 10K Ohm 1% 1/4W ±100ppm/°C Molded SMD Paper T/R; Manufacturer: Stackpole Electronics; Max Power Dissipation: 250mW; Case Code (Imperial): 0805; Case Code (Metric): 2012; Case/Package: 0805; Category: Chip SMD Resistors; Component Kind: Standard; ComponentLink1Description: https://www.seielect.com/catalog/SEI-Packaging.pdf?src-supplier=Digi-Key; ComponentLink1URL: https://www.seielect.com/catalog/SEI-Packaging.pdf?src-supplier=Digi-Key; Features: Moisture Resistant,Anti-Sulfur; Height: 0.024inch; Lead Free: Lead Free; Length: 0.079inch; Manufacturer Part Number: RNCP0805FTD10K0; Max Operating Temperature: 155°C; Min Operating Temperature: -55°C; Mount: Surface Mount; Number of Terminations: 2; Packaging: Tape and Reel; Pricing 1: 1=0.10000, 10=0.07300, 100=0.02970, 1000=0.01336, 2500=0.01159 (USD); Resistor Type: Thin Film; RoHS: Yes; RoHS Compliant: Yes; Series: RNCP; Stock 1: 1114; Supplier 1: Digi-Key; Supplier Part Number 1: RNCP0805FTD10K0CT-ND; Temperature Coefficient: 100ppm/°C; Tolerance: 1%; Width: 0.049inch; Library Name: LibEnes.SchLib
SJ1 = RES 0R 0402: Library Name: LibEnes.SchLib
